# Altium schematic sheet ESP_Trägerplatine.SchDoc(ESP_Trägerplatine)
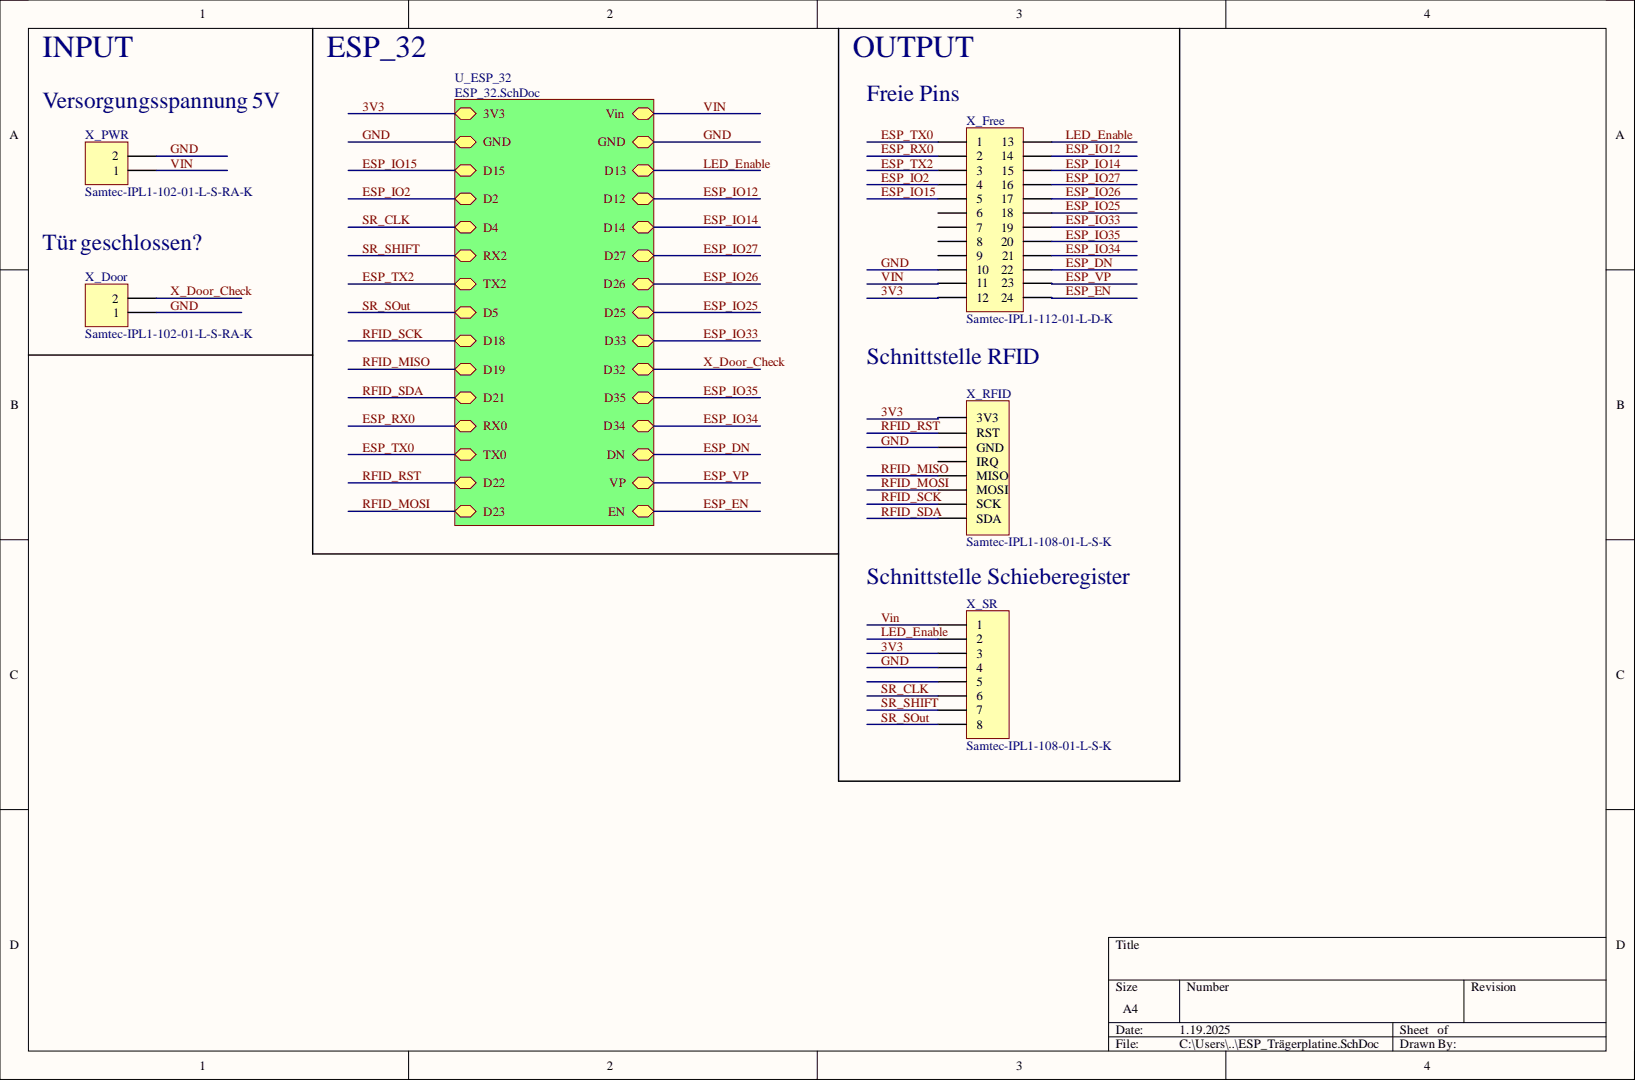
[diagram p0: ESP_Trägerplatine.SchDoc]

## Parts
X_Door  Samtec-IPL1-102-01-L-S-RA-K  [Samtec-IPL1-102-01-L-S-RA-K]
X_Free  Samtec-IPL1-112-01-L-D-K  [Samtec-IPL1-112-01-L-D-K]
X_PWR  Samtec-IPL1-102-01-L-S-RA-K  [Samtec-IPL1-102-01-L-S-RA-K]
X_RFID  Samtec-IPL1-108-01-L-S-K  [Samtec-IPL1-108-01-L-S-K]
X_SR  Samtec-IPL1-108-01-L-S-K  [Samtec-IPL1-108-01-L-S-K]

## Nets
3V3: X_Free.12 X_RFID.1(3V3) X_SR.3
ESP_DN: X_Free.22
ESP_EN: X_Free.24
ESP_IO2: X_Free.4
ESP_IO12: X_Free.14
ESP_IO14: X_Free.15
ESP_IO15: X_Free.5
ESP_IO25: X_Free.18
ESP_IO26: X_Free.17
ESP_IO27: X_Free.16
ESP_IO33: X_Free.19
ESP_IO34: X_Free.21
ESP_IO35: X_Free.20
ESP_RX0: X_Free.2
ESP_TX0: X_Free.1
ESP_TX2: X_Free.3
ESP_VP: X_Free.23
GND: X_Door.1 X_Free.10 X_PWR.2 X_RFID.3(GND) X_SR.4
LED_Enable: X_Free.13 X_SR.2
RFID_MISO: X_RFID.5(MISO)
RFID_MOSI: X_RFID.6(MOSI)
RFID_RST: X_RFID.2(RST)
RFID_SCK: X_RFID.7(SCK)
RFID_SDA: X_RFID.8(SDA)
SR_CLK: X_SR.6
SR_SHIFT: X_SR.7
SR_SOut: X_SR.8
Vin: X_Free.11 X_PWR.1 X_SR.1
X_Door_Check: X_Door.2
NetX_Free_6: X_Free.6
NetX_Free_7: X_Free.7
NetX_Free_8: X_Free.8
NetX_Free_9: X_Free.9
NetX_RFID_4: X_RFID.4(IRQ)
NetX_SR_5: X_SR.5

## Library data of the parts
X_Door X_PWR = Samtec-IPL1-102-01-L-S-RA-K: Samtec IPL1 .100", 2polig, eine Reihe, 90° gewinkelt; Component Kind: Standard; Manufacturer: Samtec; Part Number: IPL1-102-01-L-S-RA-K; Library Name: FSE_Library_connector.SchLib
X_Free = IPL1-112-01-L-D-K: Header, 12-Pin, Dual row; Component Kind: Standard; LatestRevisionDate: 17-Jul-2002; LatestRevisionNote: Re-released for DXP Platform.; Signal Integrity: Connector; Value: Samtec-IPL1-112-01-L-D-K; Library Name: FSE_Library_connector.SchLib; Publisher: Altium Limited
X_RFID = Samtec-IPL1-108-01-L-S-K: Samtec IPL1 .100", 8-polig, eine Reihe; Component Kind: Standard; Manufacturer: Samtec; Part Number: IPL1-108-01-L-S-K; Library Name: FSE_Library_connector.SchLib
X_SR = IPL1-108-01-L-S-K: Header, 8-Pin; Component Kind: Standard; LatestRevisionDate: 17-Jul-2002; LatestRevisionNote: Re-released for DXP Platform.; Value: Samtec-IPL1-108-01-L-S-K; Library Name: FSE_Library_connector.SchLib; Publisher: Altium Limited
